# Altium schematic sheet 06_PWR.SchDoc(06_PWR)
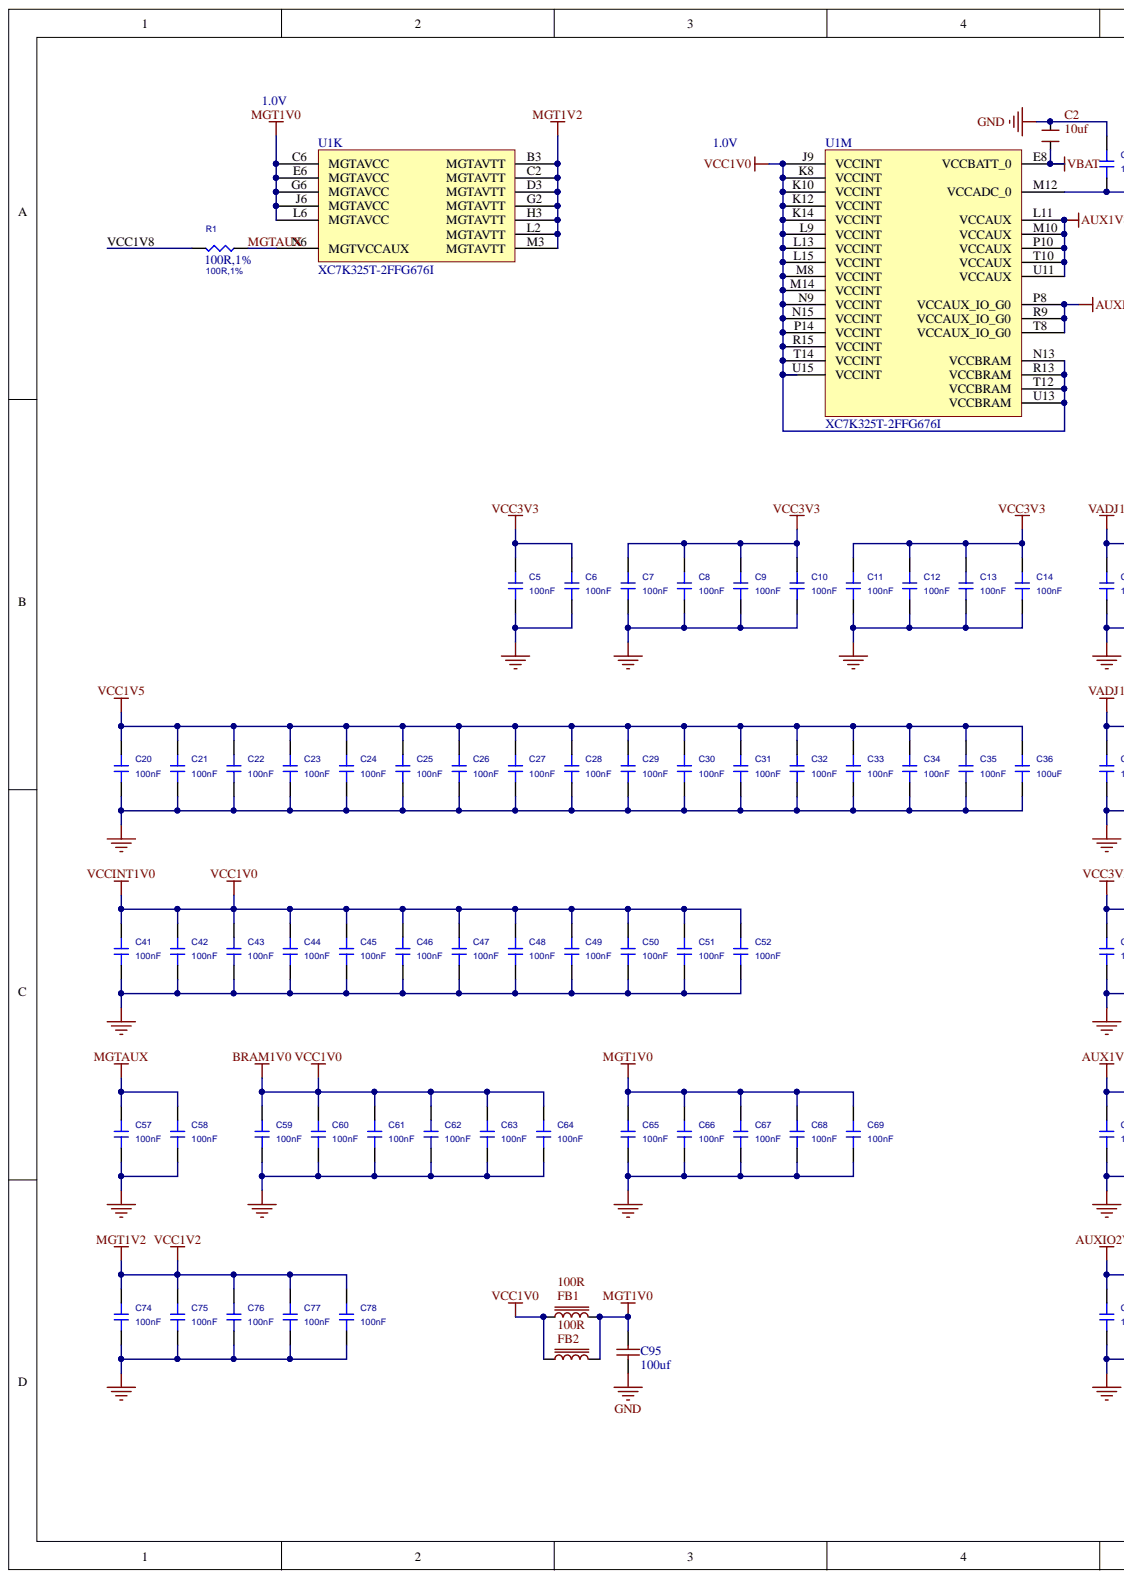
[diagram p0: 06_PWR.SchDoc, left]
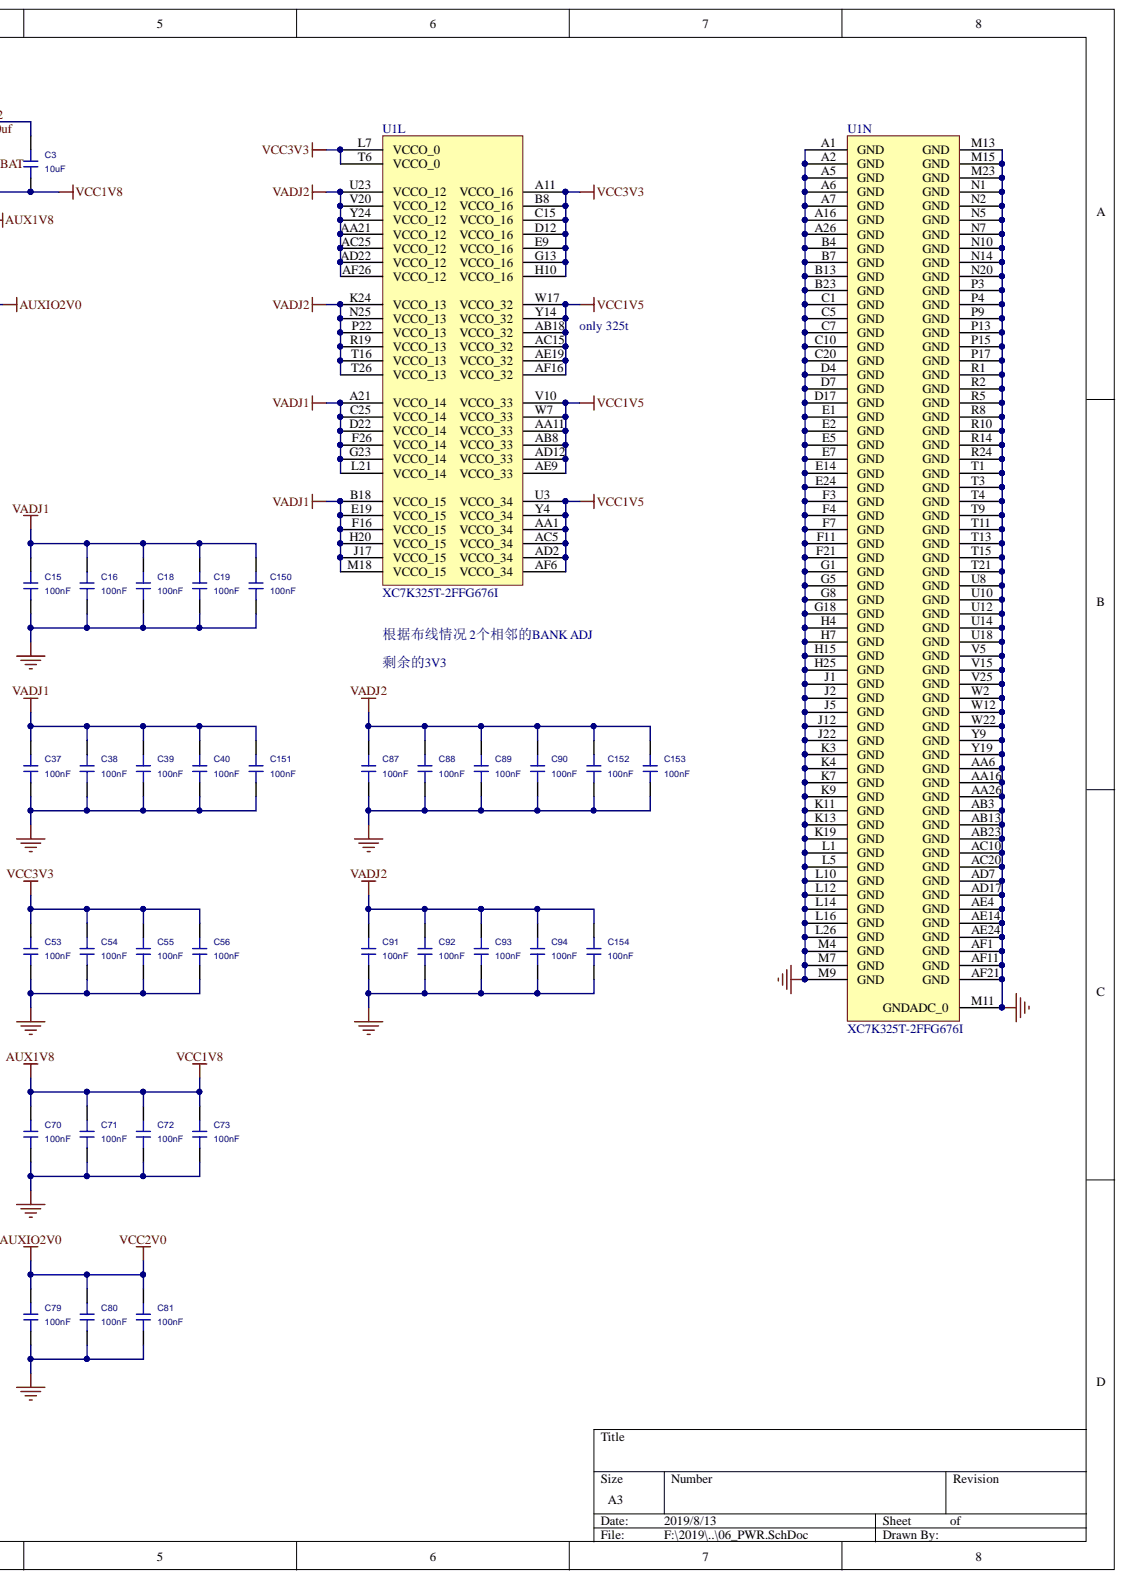
[diagram p1: 06_PWR.SchDoc, right]

## Parts
C2  10uf  [CAP0402]
C3  10uF  [C0402]
C5  100nF  [C0402]
C6  100nF  [C0402]
C7  100nF  [C0402]
C8  100nF  [C0402]
C9  100nF  [C0402]
C10  100nF  [C0402]
C11  100nF  [C0402]
C12  100nF  [C0402]
C13  100nF  [C0402]
C14  100nF  [C0402]
C15  100nF  [C0402]
C16  100nF  [C0402]
C18  100nF  [C0402]
C19  100nF  [C0402]
C20  100nF  [C0402]
C21  100nF  [C0402]
C22  100nF  [C0402]
C23  100nF  [C0402]
C24  100nF  [C0402]
C25  100nF  [C0402]
C26  100nF  [C0402]
C27  100nF  [C0402]
C28  100nF  [C0402]
C29  100nF  [C0402]
C30  100nF  [C0402]
C31  100nF  [C0402]
C32  100nF  [C0402]
C33  100nF  [C0402]
C34  100nF  [C0402]
C35  100nF  [C0402]
C36  100uF  [C1206]
C37  100nF  [C0402]
C38  100nF  [C0402]
C39  100nF  [C0402]
C40  100nF  [C0402]
C41  100nF  [C0402]
C42  100nF  [C0402]
C43  100nF  [C0402]
C44  100nF  [C0402]
C45  100nF  [C0402]
C46  100nF  [C0402]
C47  100nF  [C0402]
C48  100nF  [C0402]
C49  100nF  [C0402]
C50  100nF  [C0402]
C51  100nF  [C0402]
C52  100nF  [C0402]
C53  100nF  [C0402]
C54  100nF  [C0402]
C55  100nF  [C0402]
C56  100nF  [C0402]
C57  100nF  [C0402]
C58  100nF  [C0402]
C59  100nF  [C0402]
C60  100nF  [C0402]
C61  100nF  [C0402]
C62  100nF  [C0402]
C63  100nF  [C0402]
C64  100nF  [C0402]
C65  100nF  [C0402]
C66  100nF  [C0402]
C67  100nF  [C0402]
C68  100nF  [C0402]
C69  100nF  [C0402]
C70  100nF  [C0402]
C71  100nF  [C0402]
C72  100nF  [C0402]
C73  100nF  [C0402]
C74  100nF  [C0402]
C75  100nF  [C0402]
C76  100nF  [C0402]
C77  100nF  [C0402]
C78  100nF  [C0402]
C79  100nF  [C0402]
C80  100nF  [C0402]
C81  100nF  [C0402]
C87  100nF  [C0402]
C88  100nF  [C0402]
C89  100nF  [C0402]
C90  100nF  [C0402]
C91  100nF  [C0402]
C92  100nF  [C0402]
C93  100nF  [C0402]
C94  100nF  [C0402]
C95  100uf  [C1206]
C150  100nF  [C0402]
C151  100nF  [C0402]
C152  100nF  [C0402]
C153  100nF  [C0402]
C154  100nF  [C0402]
FB1  100R  [CAP0805]
FB2  100R  [CAP0805]
R1  100R,1%  [R0402]
U1  XC7K325T-2FFG676I  [FFG676]  (parts K,L,M,N)

## Nets
AUXIO2V0: C79.2 C80.2 C81.2 U1.P8(VCCAUX_IO_G0) U1.R9(VCCAUX_IO_G0) U1.T8(VCCAUX_IO_G0)
BRAM1V0: C41.2 C42.2 C43.2 C44.2 C45.2 C46.2 C47.2 C48.2 C49.2 C50.2 C51.2 C52.2 C59.2 C60.2 C61.2 C62.2 C63.2 C64.2 FB1.1 FB2.1 U1.J9(VCCINT) U1.K8(VCCINT) U1.K10(VCCINT) U1.K12(VCCINT) U1.K14(VCCINT) U1.L9(VCCINT) U1.L13(VCCINT) U1.L15(VCCINT) U1.M8(VCCINT) U1.M14(VCCINT) U1.N9(VCCINT) U1.N13(VCCBRAM) U1.N15(VCCINT) U1.P14(VCCINT) U1.R13(VCCBRAM) U1.R15(VCCINT) U1.T12(VCCBRAM) U1.T14(VCCINT) U1.U13(VCCBRAM) U1.U15(VCCINT)
GND: C2.1 C3.2 C5.1 C6.1 C7.1 C8.1 C9.1 C10.1 C11.1 C12.1 C13.1 C14.1 C15.1 C16.1 C18.1 C19.1 C20.1 C21.1 C22.1 C23.1 C24.1 C25.1 C26.1 C27.1 C28.1 C29.1 C30.1 C31.1 C32.1 C33.1 C34.1 C35.1 C36.1 C37.1 C38.1 C39.1 C40.1 C41.1 C42.1 C43.1 C44.1 C45.1 C46.1 C47.1 C48.1 C49.1 C50.1 C51.1 C52.1 C53.1 C54.1 C55.1 C56.1 C57.1 C58.1 C59.1 C60.1 C61.1 C62.1 C63.1 C64.1 C65.1 C66.1 C67.1 C68.1 C69.1 C70.1 C71.1 C72.1 C73.1 C74.1 C75.1 C76.1 C77.1 C78.1 C79.1 C80.1 C81.1 C87.1 C88.1 C89.1 C90.1 C91.1 C92.1 C93.1 C94.1 C95.1 C150.1 C151.1 C152.1 C153.1 C154.1 U1.A1(GND) U1.A2(GND) U1.A5(GND) U1.A6(GND) U1.A7(GND) U1.A16(GND) U1.A26(GND) U1.AA6(GND) U1.AA16(GND) U1.AA26(GND) U1.AB3(GND) U1.AB13(GND) U1.AB23(GND) U1.AC10(GND) U1.AC20(GND) U1.AD7(GND) U1.AD17(GND) U1.AE4(GND) U1.AE14(GND) U1.AE24(GND) U1.AF1(GND) U1.AF11(GND) U1.AF21(GND) U1.B4(GND) U1.B7(GND) U1.B13(GND) U1.B23(GND) U1.C1(GND) U1.C5(GND) U1.C7(GND) U1.C10(GND) U1.C20(GND) U1.D4(GND) U1.D7(GND) U1.D17(GND) U1.E1(GND) U1.E2(GND) U1.E5(GND) U1.E7(GND) U1.E14(GND) U1.E24(GND) U1.F3(GND) U1.F4(GND) U1.F7(GND) U1.F11(GND) U1.F21(GND) U1.G1(GND) U1.G5(GND) U1.G8(GND) U1.G18(GND) U1.H4(GND) U1.H7(GND) U1.H15(GND) U1.H25(GND) U1.J1(GND) U1.J2(GND) U1.J5(GND) U1.J12(GND) U1.J22(GND) U1.K3(GND) U1.K4(GND) U1.K7(GND) U1.K9(GND) U1.K11(GND) U1.K13(GND) U1.K19(GND) U1.L1(GND) U1.L5(GND) U1.L10(GND) U1.L12(GND) U1.L14(GND) U1.L16(GND) U1.L26(GND) U1.M4(GND) U1.M7(GND) U1.M9(GND) U1.M11(GNDADC_0) U1.M13(GND) U1.M15(GND) U1.M23(GND) U1.N1(GND) U1.N2(GND) U1.N5(GND) U1.N7(GND) U1.N10(GND) U1.N14(GND) U1.N20(GND) U1.P3(GND) U1.P4(GND) U1.P9(GND) U1.P13(GND) U1.P15(GND) U1.P17(GND) U1.R1(GND) U1.R2(GND) U1.R5(GND) U1.R8(GND) U1.R10(GND) U1.R14(GND) U1.R24(GND) U1.T1(GND) U1.T3(GND) U1.T4(GND) U1.T9(GND) U1.T11(GND) U1.T13(GND) U1.T15(GND) U1.T21(GND) U1.U8(GND) U1.U10(GND) U1.U12(GND) U1.U14(GND) U1.U18(GND) U1.V5(GND) U1.V15(GND) U1.V25(GND) U1.W2(GND) U1.W12(GND) U1.W22(GND) U1.Y9(GND) U1.Y19(GND)
MGT1V0: C65.2 C66.2 C67.2 C68.2 C69.2 C95.2 FB1.2 FB2.2 U1.C6(MGTAVCC) U1.E6(MGTAVCC) U1.G6(MGTAVCC) U1.J6(MGTAVCC) U1.L6(MGTAVCC)
MGT1V2: C74.2 C75.2 C76.2 C77.2 C78.2 U1.B3(MGTAVTT) U1.C2(MGTAVTT) U1.D3(MGTAVTT) U1.G2(MGTAVTT) U1.H3(MGTAVTT) U1.L2(MGTAVTT) U1.M3(MGTAVTT)
VADJ1: C15.2 C16.2 C18.2 C19.2 C37.2 C38.2 C39.2 C40.2 C150.2 C151.2 U1.A21(VCCO_14) U1.B18(VCCO_15) U1.C25(VCCO_14) U1.D22(VCCO_14) U1.E19(VCCO_15) U1.F16(VCCO_15) U1.F26(VCCO_14) U1.G23(VCCO_14) U1.H20(VCCO_15) U1.J17(VCCO_15) U1.L21(VCCO_14) U1.M18(VCCO_15)
VADJ2: C87.2 C88.2 C89.2 C90.2 C91.2 C92.2 C93.2 C94.2 C152.2 C153.2 C154.2 U1.AA21(VCCO_12) U1.AC25(VCCO_12) U1.AD22(VCCO_12) U1.AF26(VCCO_12) U1.K24(VCCO_13) U1.N25(VCCO_13) U1.P22(VCCO_13) U1.R19(VCCO_13) U1.T16(VCCO_13) U1.T26(VCCO_13) U1.U23(VCCO_12) U1.V20(VCCO_12) U1.Y24(VCCO_12)
VBAT: C2.2 U1.E8(VCCBATT_0)
VCC1V5: C20.2 C21.2 C22.2 C23.2 C24.2 C25.2 C26.2 C27.2 C28.2 C29.2 C30.2 C31.2 C32.2 C33.2 C34.2 C35.2 C36.2 U1.AA1(VCCO_34) U1.AA11(VCCO_33) U1.AB8(VCCO_33) U1.AB18(VCCO_32) U1.AC5(VCCO_34) U1.AC15(VCCO_32) U1.AD2(VCCO_34) U1.AD12(VCCO_33) U1.AE9(VCCO_33) U1.AE19(VCCO_32) U1.AF6(VCCO_34) U1.AF16(VCCO_32) U1.U3(VCCO_34) U1.V10(VCCO_33) U1.W7(VCCO_33) U1.W17(VCCO_32) U1.Y4(VCCO_34) U1.Y14(VCCO_32)
VCC3V3: C5.2 C6.2 C7.2 C8.2 C9.2 C10.2 C11.2 C12.2 C13.2 C14.2 C53.2 C54.2 C55.2 C56.2 U1.A11(VCCO_16) U1.B8(VCCO_16) U1.C15(VCCO_16) U1.D12(VCCO_16) U1.E9(VCCO_16) U1.G13(VCCO_16) U1.H10(VCCO_16) U1.L7(VCCO_0) U1.T6(VCCO_0)
MGTAUX: C57.2 C58.2 R1.2 U1.N6(MGTVCCAUX)
VCC1V8: C3.1 C70.2 C71.2 C72.2 C73.2 R1.1 U1.L11(VCCAUX) U1.M10(VCCAUX) U1.M12(VCCADC_0) U1.P10(VCCAUX) U1.T10(VCCAUX) U1.U11(VCCAUX)
